# Altium schematic sheet TopLevel.SchDoc(TopLevel)
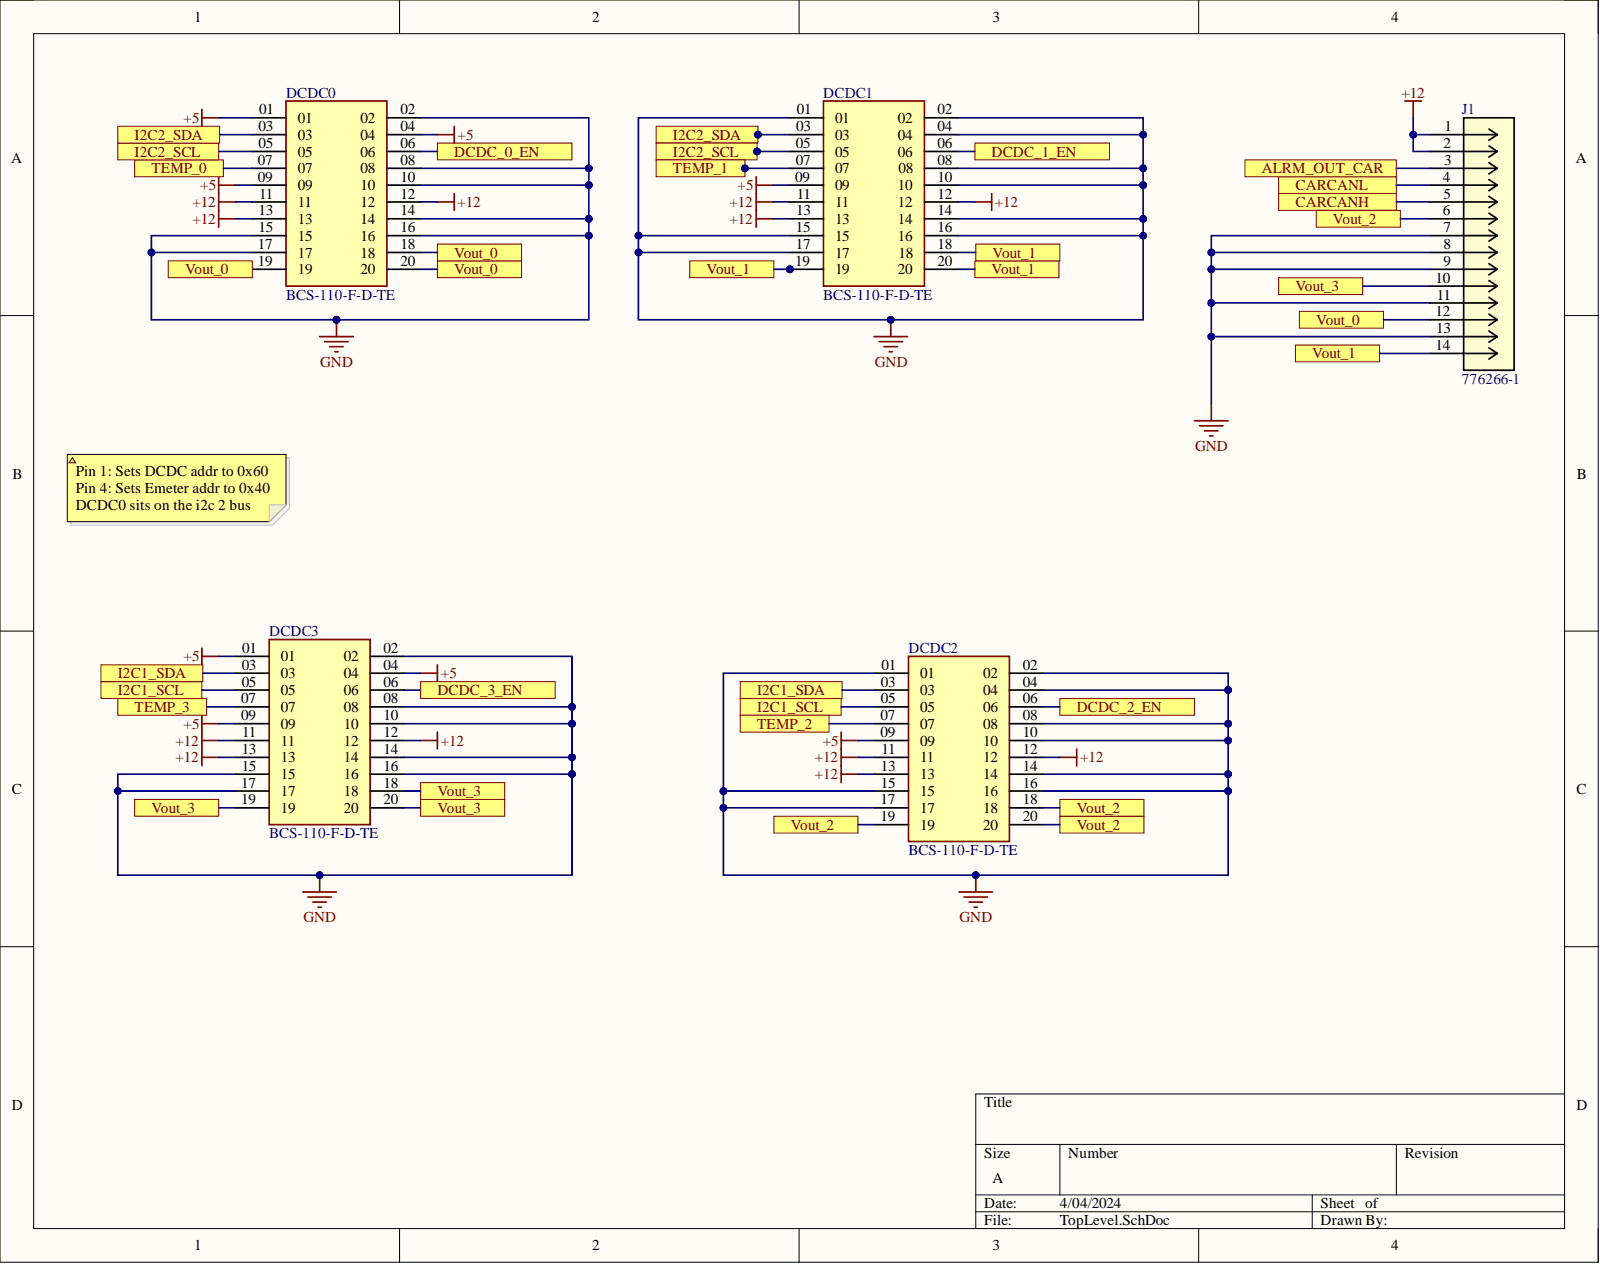
[diagram p0: TopLevel.SchDoc]

## Parts
DCDC0  BCS-110-F-D-TE
DCDC1  BCS-110-F-D-TE
DCDC2  BCS-110-F-D-TE
DCDC3  BCS-110-F-D-TE
J1  776266-1

## Ports
ALRM_OUT_CAR, CARCANH, CARCANL, DCDC_0_EN, DCDC_1_EN, DCDC_2_EN, DCDC_3_EN, I2C1_SCL, I2C1_SDA, I2C2_SCL, I2C2_SDA, TEMP_0, TEMP_1, TEMP_2, TEMP_3, Vout_0, Vout_1, Vout_2, Vout_3

## Nets
+5: DCDC0.01 DCDC0.04 DCDC0.09 DCDC1.09 DCDC2.09 DCDC3.01 DCDC3.04 DCDC3.09
+12: DCDC0.11 DCDC0.12 DCDC0.13 DCDC1.11 DCDC1.12 DCDC1.13 DCDC2.11 DCDC2.12 DCDC2.13 DCDC3.11 DCDC3.12 DCDC3.13 J1.1 J1.2
GND: DCDC0.02 DCDC0.08 DCDC0.10 DCDC0.14 DCDC0.15 DCDC0.16 DCDC0.17 DCDC1.01 DCDC1.02 DCDC1.04 DCDC1.08 DCDC1.10 DCDC1.14 DCDC1.15 DCDC1.16 DCDC1.17 DCDC2.01 DCDC2.02 DCDC2.04 DCDC2.08 DCDC2.10 DCDC2.14 DCDC2.15 DCDC2.16 DCDC2.17 DCDC3.02 DCDC3.08 DCDC3.10 DCDC3.14 DCDC3.15 DCDC3.16 DCDC3.17 J1.7 J1.8 J1.9 J1.11 J1.13
ALRM_OUT_CAR: J1.3 ; port ALRM_OUT_CAR
CARCANH: J1.5 ; port CARCANH
CARCANL: J1.4 ; port CARCANL
DCDC_0_EN: DCDC0.06 ; port DCDC_0_EN
DCDC_1_EN: DCDC1.06 ; port DCDC_1_EN
DCDC_2_EN: DCDC2.06 ; port DCDC_2_EN
DCDC_3_EN: DCDC3.06 ; port DCDC_3_EN
I2C1_SCL: DCDC2.05 DCDC3.05 ; port I2C1_SCL
I2C1_SDA: DCDC2.03 DCDC3.03 ; port I2C1_SDA
I2C2_SCL: DCDC0.05 DCDC1.05 ; port I2C2_SCL
I2C2_SDA: DCDC0.03 DCDC1.03 ; port I2C2_SDA
TEMP_0: DCDC0.07 ; port TEMP_0
TEMP_1: DCDC1.07 ; port TEMP_1
TEMP_2: DCDC2.07 ; port TEMP_2
TEMP_3: DCDC3.07 ; port TEMP_3
Vout_0: DCDC0.18 DCDC0.19 DCDC0.20 J1.12 ; port Vout_0
Vout_1: DCDC1.18 DCDC1.19 DCDC1.20 J1.14 ; port Vout_1
Vout_2: DCDC2.18 DCDC2.19 DCDC2.20 J1.6 ; port Vout_2
Vout_3: DCDC3.18 DCDC3.19 DCDC3.20 J1.10 ; port Vout_3

## Library data of the parts
DCDC0-DCDC3 = CMP-158-000000-1: MF: Samtec Inc.; Availability: Unavailable; Component Kind: Standard; Component Type: Standard; Design Item ID: BCS-110-F-D-TE; MP: BCS-110-F-D-TE; Package: None; Pin Count: 20; Price: None; Source: BCS-110-F-D-TE.SchLib; Library Name: Illini Formula Electric
J1 = CMP-154-000000-1: No Description Available; Mfr_Name: TE Connectivity; Component Kind: Standard; Design Item ID: 776266-1; RefDes: RefDes; Source: 776266-1.SchLib; TYPE: -; Library Name: Illini Formula Electric
